# Altium schematic sheet PSU + RTC.SchDoc
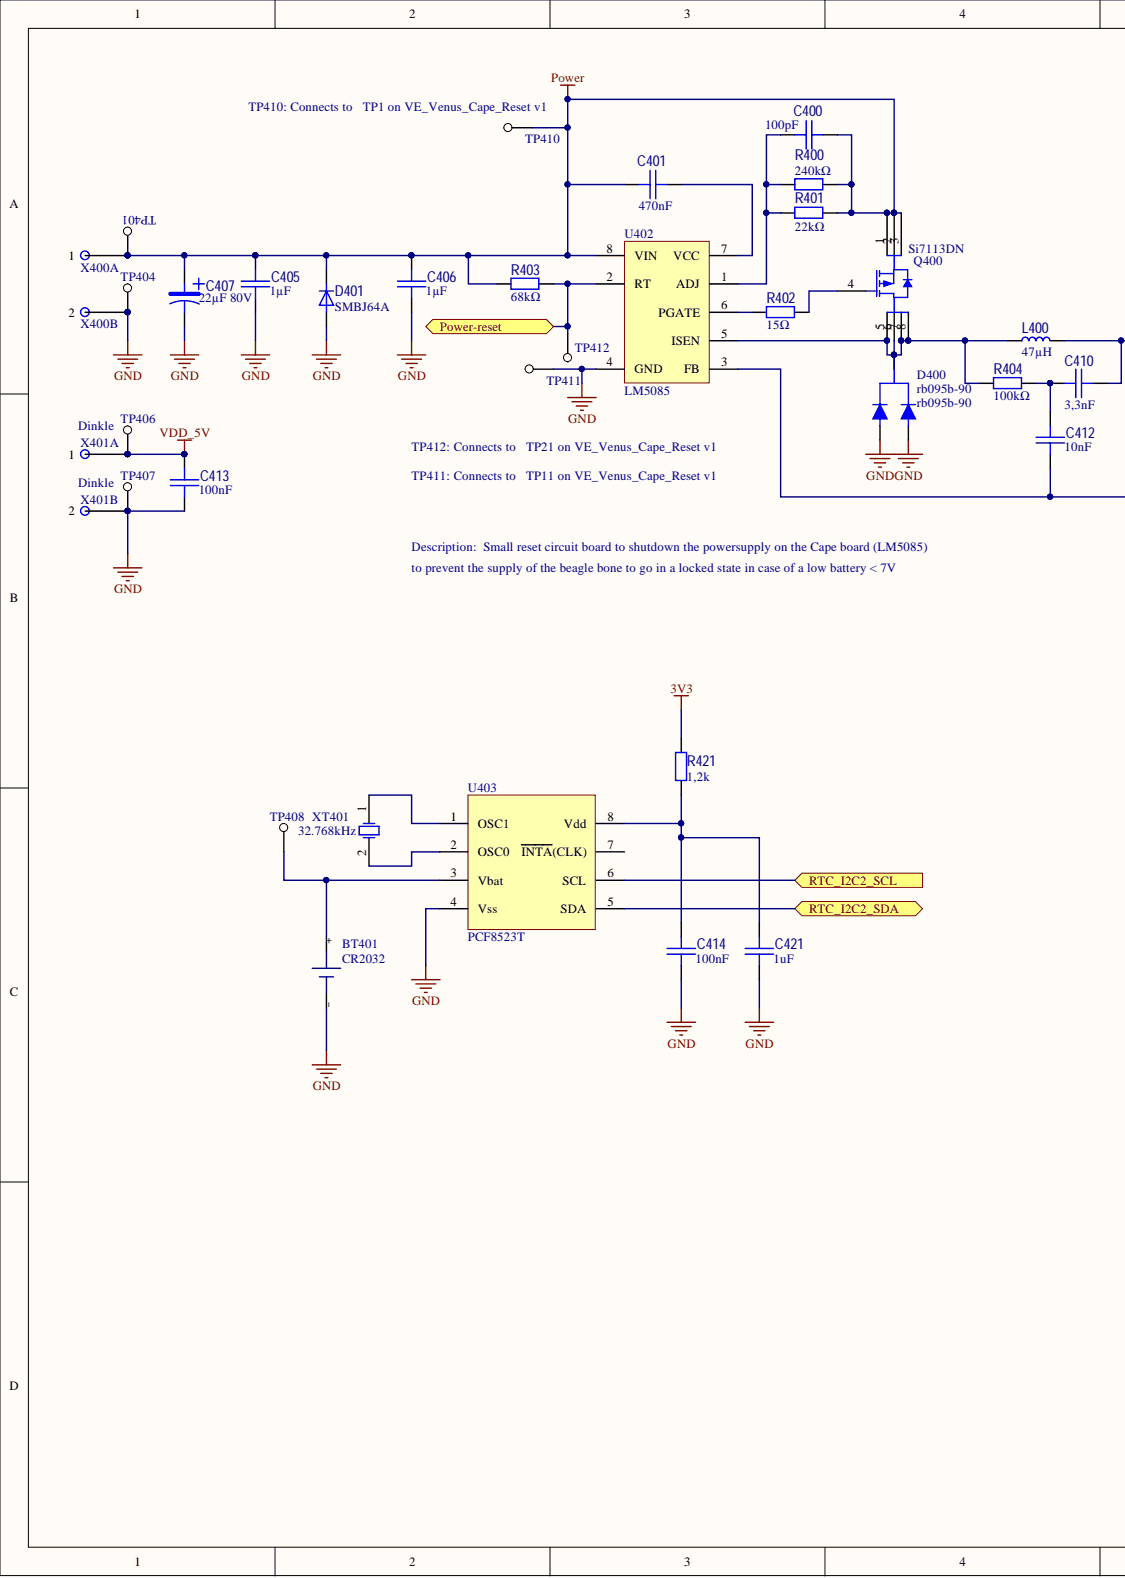
[diagram p0: PSU + RTC.SchDoc, left]
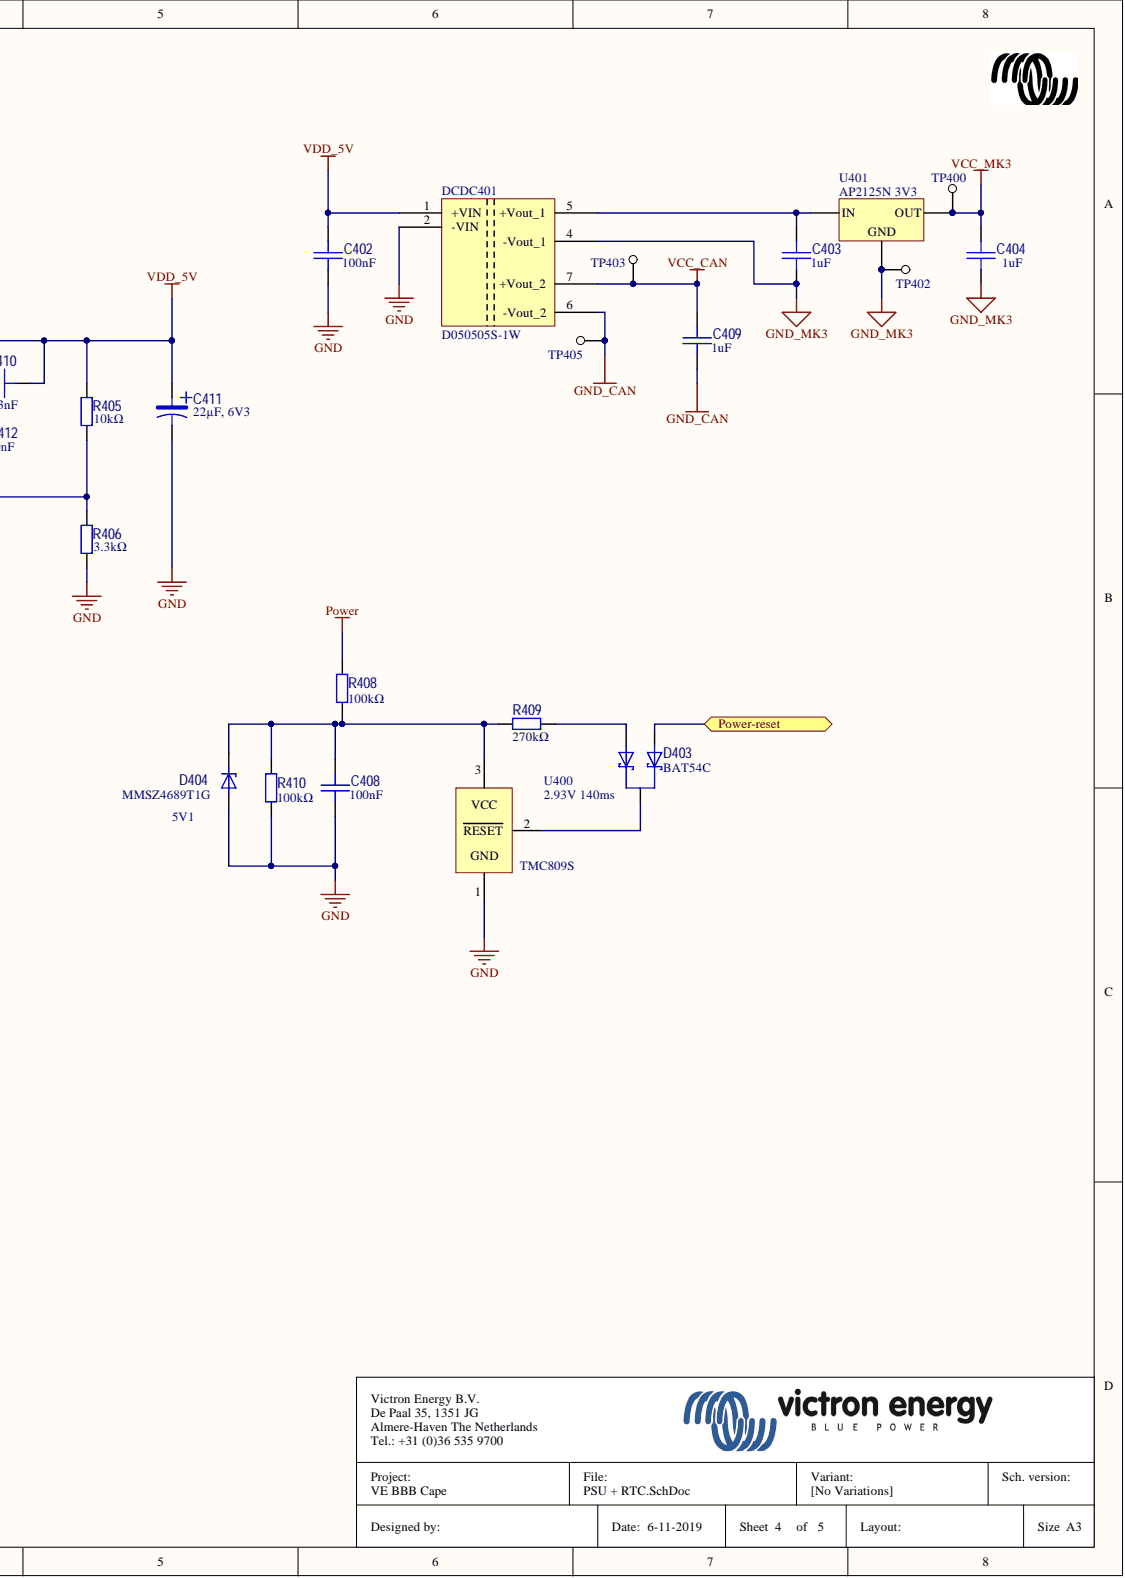
[diagram p1: PSU + RTC.SchDoc, right]

## Parts
BT401  CR2032  [CR2032HFN]
C400  value 100pF  [C0805_R]  (hidden comment: 100419)
C401  value 470nF  [C0805_R]  (hidden comment: 1044)
C402  value 100nF  [C0805_R]  (hidden comment: 1035)
C403  value 1uF  [C0805_R]  (hidden comment: 100185)
C404  value 1uF  [C0805_R]  (hidden comment: 100185)
C405  value 1µF  [C1206_R]  (hidden comment: 100237)
C406  value 1µF  [C1206_R]  (hidden comment: 100237)
C407  value 22µF 80V  [ELCO_8X10_V]  (hidden comment: 100401)
C408  value 100nF  [C0805_R]  (hidden comment: 1035)
C409  value 1uF  [C0805_R]  (hidden comment: 100185)
C410  value 3,3nF  [C0805_R]  (hidden comment: 1082)
C411  value 22µF, 6V3  [ELCO_6.3X5.8_V]  (hidden comment: 100402)
C412  value 10nF  [C0805_R]  (hidden comment: 100420)
C413  value 100nF  [C0805_R]  (hidden comment: 1035)
C414  value 100nF  [C0805_R]  (hidden comment: 1035)
C421  value 1uF  [C0805_R]  (hidden comment: 1035)
D400  rb095b-90  [TO252_R]
D401  value SMBJ64A  [SMB/DO-214AA]  (hidden comment: 4014)
D403  BAT54C  [SOT23_R]
D404  MMSZ4689T1G  [SOD123_R]
DCDC401  value D050505S-1W  MAN_PARTNUMBER: D050505S-1W  [Recom SIP DCDC 1W]  (hidden comment: D_S-1W)
L400  value 47µH  [WE-PD-XXL]  (hidden comment: 101828)
Q400  Si7113DN  [PowerPak 1212-8]
R400  value 240kΩ  [R0805_R]  (hidden comment: 429)
R401  value 22kΩ  [R0805_R]  (hidden comment: 404)
R402  value 15Ω  [R0805_R]  (hidden comment: 328)
R403  value 68kΩ  [R0805_R]  (hidden comment: 416)
R404  value 100kΩ  [R0805_R]  (hidden comment: 420)
R405  value 10kΩ  [R0805_R]  (hidden comment: 396)
R406  value 3.3kΩ  [R0805_R]  (hidden comment: 384)
R408  value 100kΩ  [R0805_R]  (hidden comment: 420)
R409  value 270kΩ  [R0805_R]  (hidden comment: 420)
R410  value 100kΩ  [R0805_R]  (hidden comment: 420)
R421  value 1,2k  [R0805_R]  (hidden comment: 420)
TP400  CN1  [testpad_round_1mm]  (value not drawn)  (no BOM)
TP401  CN1  [testpad_round_1mm]  (value not drawn)  (no BOM)
TP402  CN1  [testpad_round_1mm]  (value not drawn)  (no BOM)
TP403  CN1  [testpad_round_1mm]  (value not drawn)  (no BOM)
TP404  CN1  [testpad_round_1mm]  (value not drawn)  (no BOM)
TP405  CN1  [testpad_round_1mm]  (value not drawn)  (no BOM)
TP406  CN1  [testpad_round_1mm]  (value not drawn)  (no BOM)
TP407  CN1  [testpad_round_1mm]  (value not drawn)  (no BOM)
TP408  CN1  [testpad_round_1mm]  (value not drawn)  (no BOM)
TP410  CN1  [testpad_round_1mm]  (value not drawn)  (no BOM)
TP411  CN1  [testpad_round_1mm]  (value not drawn)  (no BOM)
TP412  CN1  [testpad_round_1mm]  (value not drawn)  (no BOM)
U400  value 2.93V 140ms  [SOT23_R]  (hidden comment: MAX803)
U401  value AP2125N 3V3  [SOT23_R]  (hidden comment: regulator)
U402  LM5085  [HVSSOP-8]
U403  PCF8523T  [SO8_R]
X400  pluggable terminal 2P  [2EHDRC-2P]  (value not drawn)  (parts A,B)
X401  value Dinkle  MANUFACTURER: Dinkle  [ECH350R-2P]  (hidden comment: 2 pin terminal)  (parts A,B)
XT401  32.768kHz  [ABRA-ABS07-2_V]

## Ports
Power-reset, RTC_I2C2_SCL, RTC_I2C2_SDA

## Nets
3V3: R421.2
GND: BT401.1 C402.2 C405.2 C406.2 C407.2 C408.2 C411.2 C413.2 C414.2 C421.2 D400.1 D400.3 D401.2 D404.2 DCDC401.2(-VIN) R406.2 R410.1 TP404.1 TP407.1 TP411.1 U400.1 U402.4(GND) U403.4(Vss) X400.2 X401.2
GND_CAN: C409.2 DCDC401.6(-Vout_2) TP405.1
GND_MK3: C403.2 C404.2 DCDC401.4(-Vout_1) TP402.1 U401.1
Power: C400.2 C401.1 C405.1 C406.1 C407.1 D401.1 Q400.1 Q400.2 Q400.3 R400.2 R401.2 R403.2 R408.2 TP401.1 TP410.1 U402.8(VIN) X400.1
VCC_CAN: C409.1 DCDC401.7(+Vout_2) TP403.1
VCC_MK3: C404.1 TP400.1 U401.2
VDD_5V: C402.1 C410.2 C411.1 C413.1 DCDC401.1(+VIN) L400.1 R405.1 TP406.1 X401.1
Power-reset: D403.1 R403.1 TP412.1 U402.2(RT) ; port Power-reset
RTC_I2C2_SCL: U403.6(SCL) ; port RTC_I2C2_SCL
RTC_I2C2_SDA: U403.5(SDA) ; port RTC_I2C2_SDA
NetBT401_0: BT401.0 TP408.1 U403.3(Vbat)
NetC400_1: C400.1 R400.1 R401.1 U402.1(ADJ)
NetC401_2: C401.2 U402.7(VCC)
NetC403_1: C403.1 DCDC401.5(+Vout_1) U401.3
NetC408_1: C408.1 D404.1 R408.1 R409.2 R410.2 U400.3
NetC410_1: C410.1 C412.2 R404.2
NetC412_1: C412.1 R405.2 R406.1 U402.3(FB)
NetC414_1: C414.1 C421.1 R421.1 U403.8(Vdd)
NetD400_4: D400.4 L400.2 Q400.5 Q400.6 Q400.7 Q400.8 R404.1 U402.5(ISEN)
NetD403_2: D403.2 R409.1
NetD403_3: D403.3 U400.2
NetQ400_4: Q400.4 R402.1
NetR402_2: R402.2 U402.6(PGATE)
NetU403_1: U403.1(OSC1) XT401.1
NetU403_2: U403.2(OSC0) XT401.2

Not connected: U403 7(INTA(CLK))

## Library data of the parts
BT401 = 101562: CR2032 solder pins; MANUFACTURER: Panasonic; Cls: MECH; Database Table Name: Mechanical; Component Kind: Standard; MAN_PARTNUMBER: CR2032HFN; Part: 101562; PartID: 101562; Supplier 1: Digikey; Supplier Part Number 1: P666-ND; Supplier Price 1: 0,608; Value: CR2032; Library Name: VictronAltiumComponents.SVNDBLib
C400 = 100419: MAN_PARTNUMBER: C0805C101J1GACTU; MANUFACTURER: KEMET; Part_Description: CAP,100PF,100V,0805,NP0; Cls: CAP; Database Table Name: COMPDATA$; Shape: 805; Tol: 0.05; VENDOR: Digikey; VENDOR_PRICE: 0.01426; VENDOR_STOCK_NR: 399-1123-2-ND; Voltage: 100V; Component Kind: Standard; Part: 100419; Library Name: Data Base Librarys.DbLib
C401 = 1044: INDIC_PARTNO: CSEC470NFH0KFYAY; MAN_PARTNUMBER: CC0805KKX7R9BB474; MANUFACTURER: Yageo; Part_Description: Cap, Smd Ml.Ceramic 0805 470NF 10% 50V X7R, Yageo; Cls: CAP; Database Table Name: COMPDATA$; INDIC_PRICE: 0.0315; Shape: 805; Tol: 0.1; VENDOR: Digikey; VENDOR_PRICE: 0.05409; VENDOR_STOCK_NR: 311-1889-1-ND; Voltage: 50V; Component Kind: Standard; Part: 1044; Library Name: Data Base Librarys.DbLib
C402 C408 C413 C414 = 1035: Cap, SMD 0805, ceramic, X7R, 100nF, 50V, 10%, Yageo; INDIC_PARTNO: CSE100NF0805YAGY; MAN_PARTNUMBER: CC0805KPX7R9BB104; Database Table Name: AllComponents; INDIC_PRICE: 0,068; Shape: 805; Tol: 10,00%; Cls: CAP; Component Kind: Standard; MANUFACTURER: Yageo; Part: 1035; PartID: 1035; Supplier 1: Mouser; Supplier Part Number 1: 603-CC805KPX7R9BB104; Supplier Price 1: 0,005; Voltage: 50V; Library Name: VictronAltiumComponents.SVNDBLib
C403 C404 C409 = 100185: MAN_PARTNUMBER: C0805C105K4RACTU; MANUFACTURER: Kemet; Part_Description: Cap, MLCC, X7R, 16V, 10%, 0805, 1uF; Cls: CAP; Database Table Name: COMPDATA$; VENDOR: Digikey; VENDOR_PRICE: 0.0222; VENDOR_STOCK_NR: 399-1284-1-ND; Component Kind: Standard; Part: 100185; Library Name: Data Base Librarys.DbLib
C405 C406 = 100237: MAN_PARTNUMBER: C1206C105K1RACTU; MANUFACTURER: Kemet; Part_Description: CAP, MLCC, X7R, 1UF, 100V, 1206; Cls: CAP; Database Table Name: COMPDATA$; Shape: 1206; VENDOR: Digikey; VENDOR_PRICE: 0.13915; VENDOR_STOCK_NR: 399-11683-1-ND; Component Kind: Standard; Part: 100237; Library Name: Data Base Librarys.DbLib
C407 = 100401: MAN_PARTNUMBER: EEH-ZA1K220P; MANUFACTURER: Panasonic; Part_Description: Cap polarized, hybrid polymer. 80V 22µF; Cls: CAP; Database Table Name: COMPDATA$; VENDOR: Mouser; VENDOR_PRICE: 0.958; VENDOR_STOCK_NR: 667-EEH-ZA1K220P; Component Kind: Standard; Part: 100401; Library Name: Data Base Librarys.DbLib
C410 = 1082: INCAP_PARTNO: 1005520681; MAN_PARTNUMBER: C0805KRX7R0BB332; MANUFACTURER: Yageo; Part_Description: CAP,3.3nF,100V, 0805; Cls: CAP; Database Table Name: COMPDATA$; INCAP_PRICE: 0.0032; Shape: 805; VENDOR: Digikey; VENDOR_PRICE: 0.01802; VENDOR_STOCK_NR: 311-1471-2-ND; Component Kind: Standard; Part: 1082; Library Name: Data Base Librarys.DbLib
C411 = 100402: MAN_PARTNUMBER: 6SVP220M; MANUFACTURER: Panasonic; Part_Description: Cap polarized, polymer. 6.3V 220µF; Cls: CAP; Database Table Name: COMPDATA$; VENDOR: Mouser; VENDOR_PRICE: 0.339; VENDOR_STOCK_NR: 667-6SVP220M; Component Kind: Standard; Part: 100402; Library Name: Data Base Librarys.DbLib
C412 = 100420: MAN_PARTNUMBER: C0805C101K5RACTU; MANUFACTURER: KEMET; Part_Description: CAP,10nF,MLC X7R 50V,0805; Cls: CAP; Database Table Name: COMPDATA$; Shape: 805; Tol: 0.1; VENDOR: Digikey; VENDOR_PRICE: 0.038; VENDOR_STOCK_NR: 399-7981-2-ND; Voltage: 50V; Component Kind: Standard; Part: 100420; Library Name: Data Base Librarys.DbLib
C421 = 1035: Cap, SMD 0805, ceramic, X7R, 100nF, 50V, 10%, Yageo; INDIC_PARTNO: CSE100NF0805YAGY; MAN_PARTNUMBER: CC0805KPX7R9BB104; Database Table Name: AllComponents; INDIC_PRICE: 0,068; Shape: 805; Tol: 10,00%; Voltage: 10V; Cls: CAP; Component Kind: Standard; MANUFACTURER: Yageo; Part: 1035; PartID: 1035; Supplier 1: Mouser; Supplier Part Number 1: 603-CC805KPX7R9BB104; Supplier Price 1: 0,005; Library Name: VictronAltiumComponents.SVNDBLib
D400 = 101551: MANUFACTURER: Rohm; Cls: DIO; Database Table Name: AllComponents; Shape: TO 252 Dpak; Component Kind: Standard; Description: rb095b-90; MAN_PARTNUMBER: rb095b-90; Part: 101551; PartID: 101551; Supplier 1: Digikey; Supplier Part Number 1: RB095B-90TLTR-ND; Supplier Price 1: 0,3598; Value: rb095b-90; Library Name: VictronAltiumComponents.SVNDBLib
D401 = 4014: MAN_PARTNUMBER: ES2B; MANUFACTURER: Vishay; Part_Description: Diode,SMD ,2A 100V, ES2B, SMB; Cls: DIO; Database Table Name: COMPDATA$; VENDOR: Farnell; VENDOR_PRICE: 0.154; VENDOR_STOCK_NR: 9549250; Component Kind: Standard; Part: 4014; Library Name: Data Base Librarys.DbLib
D403 = 4003: Diode, SMD, 30V, 200mA, BAT54C, SOT23, dual common cathode; ACM_PARTNO: 8579; INCAP_PARTNO: 1009100229; IRRICHI_PARTNO: 2403314730000; MAN_PARTNUMBER: BAT54C,215; MANUFACTURER: Nexperia; ACM_PRICE: 0,0151; Cls: DIO; Current: 0.2; Database Table Name: AllComponents; INCAP_PRICE: 0,0084; IRRICHI_PRICE: 0,016; Voltage: 30; Component Kind: Standard; Part: 4003; PartID: 4003; Shape: SOT23; Supplier 1: Farnell; Supplier Part Number 1: 1081192; Supplier Price 1: 0,009; Value: BAT54C; Library Name: VictronAltiumComponents.SVNDBLib
D404 = 101698: Diode, SMD, Zener, 15V, 500mW, MMSZ5245B-TP; ACM_PARTNO: 0DIZN-041PbF; MANUFACTURER: MCC; ACM_PRICE: 0,0187; Cls: DIO; Current: 0.5; Database Table Name: AllComponents; Shape: SOD123; Voltage: 15; Component Kind: Standard; ComponentLink1Description: Datasheet; ComponentLink1URLSrc: http://www.mccsemi.com/up_pdf/MMSZ5221-MMSZ5261(SOD123).pdf; MAN_PARTNUMBER: MMSZ5245B-TP; Part: 101698; PartID: 101698; Supplier 1: Digikey; Supplier Part Number 1: MMSZ5245B-TPMSTR-ND; Supplier Price 1: 0,010; Value: MMSZ4689T1G; Library Name: VictronAltiumComponents.SVNDBLib
DCDC401 = 101516: IC, controller, buck, 5V, 100mA, Mornsun unregulated twin DC-DC Converter; Database Table Name: AllComponents; Shape: TH; Cls: IC; Component Kind: Standard; MANUFACTURER: Mornsun; Part: 101516; PartID: 101516; Supplier Price 1: 2,28; Library Name: VictronAltiumComponents.SVNDBLib
L400 = 101828: Choke SMD 47µH; MAN_PARTNUMBER: SRR1210A-470M; MANUFACTURER: Bourns; Cls: IND; Database Table Name: Inductor; Shape: TH; Component Kind: Standard; Part: 101828; PartID: 101828; Supplier 1: Digikey; Supplier Part Number 1: SRR1210A-470MCT-ND; Supplier Price 1: 0,51870; Library Name: VictronAltiumComponents.SVNDBLib
Q400 = 100400: MAN_PARTNUMBER: SI7113DN-T1-GE3; MANUFACTURER: Vishay Siliconix; Part_Description: PMOS, SI7113DN, -100V 134mOhm, PowerPAK SO8; Cls: FET; Database Table Name: COMPDATA$; VENDOR: Mouser; VENDOR_PRICE: 0.669; VENDOR_STOCK_NR: 781-SI7113DN-T1-GE3; Component Kind: Standard; Part: 100400; Value: Si7113DN; Library Name: Data Base Librarys.DbLib
R400 = 429: MAN_PARTNUMBER: RC0805FR-07240KL; MANUFACTURER: YAGEO; Part_Description: RES,MF,240K, 0.125W 1% 0805; Cls: RES; Database Table Name: COMPDATA$; INCAP_PRICE: 0.0014; Power: 0,125W; Shape: 805; Tol: 0.01; VENDOR: Mouser; VENDOR_PRICE: 0.003; VENDOR_STOCK_NR: 603-RC0805FR-07240KL; Component Kind: Standard; ComponentLink1Description: \datasheets\Yageo-RC0805FR-0710KL-datasheet.pdf; Part: 429; Library Name: Data Base Librarys.DbLib
R401 = 404: INCAP_PARTNO: 1035031293; INDIC_PARTNO: RSCEE0022KF97MUY; MAN_PARTNUMBER: RC0805FR-0722KL; MANUFACTURER: YAGEO; Part_Description: RES,MF,22K   0.125W 1% 0805; Cls: RES; Database Table Name: COMPDATA$; INCAP_PRICE: 0.0014; INDIC_PRICE: 0.0023; Power: 0,125W; Shape: 805; Tol: 0.01; VENDOR: Mouser; VENDOR_PRICE: 0.003; VENDOR_STOCK_NR: 603-RC0805FR-0722KL; Component Kind: Standard; ComponentLink1Description: \datasheets\Yageo-RC0805FR-0710KL-datasheet.pdf; Part: 404; Library Name: Data Base Librarys.DbLib
R402 = 328: Res, SMD 0805, MF, 15E, 0.125W 1% 0805; MANUFACTURER: YAGEO; Database Table Name: Resistor; INCAP_PRICE: 0,0009; Power: 0,125W; Shape: 0805; Tol: 1,00%; Cls: RES; Component Kind: Standard; ComponentLink1Description: \datasheets\Yageo-RC0805FR-0710KL-datasheet.pdf; Part: 328; PartID: 328; Library Name: VictronAltiumComponents.SVNDBLib
R403 = 416: INDIC_PARTNO: RSCE68KXXFB8NYX; MAN_PARTNUMBER: RC0805FR-0768KL; MANUFACTURER: YAGEO; Part_Description: RES,MF,68K, 0.125W 1% 0805; Cls: RES; Database Table Name: COMPDATA$; INCAP_PRICE: 0.0014; INDIC_PRICE: 0.0018; Power: 0,125W; Shape: 805; Tol: 0.01; VENDOR: Mouser; VENDOR_PRICE: 0.003; VENDOR_STOCK_NR: 603-RC0805FR-0768KL; Component Kind: Standard; ComponentLink1Description: \datasheets\Yageo-RC0805FR-0710KL-datasheet.pdf; Part: 416; Library Name: Data Base Librarys.DbLib
R404 R408-R410 R421 = 420: Res, SMD 0805, MF, 100K 0.125W 1% 0805; INCAP_PARTNO: 1035031298; INDIC_PARTNO: RSCE100KXFB8NYX; MAN_PARTNUMBER: RC0805FR-07 100KL; MANUFACTURER: YAGEO; Database Table Name: Resistor; INCAP_PRICE: 0,0009; INDIC_PRICE: 0,0008; Power: 0,125W; Shape: 0805; Tol: 1,00%; Cls: RES; Component Kind: Standard; ComponentLink1Description: \datasheets\Yageo-RC0805FR-0710KL-datasheet.pdf; Part: 420; PartID: 420; Library Name: VictronAltiumComponents.SVNDBLib
R405 = 396: INCAP_PARTNO: 1035031290; INDIC_PARTNO: RSCE00010KFB7YAY; MAN_PARTNUMBER: RC0805FR-0710KL; MANUFACTURER: YAGEO; Part_Description: RES,MF,10K  0.125W 1%0805; Cls: RES; Database Table Name: COMPDATA$; INCAP_PRICE: 0.0014; INDIC_PRICE: 0.0018; Power: 0,125W; Shape: 805; Tol: 0.01; VENDOR: Mouser; VENDOR_PRICE: 0.003; VENDOR_STOCK_NR: 603-RC0805FR-0710KL; Component Kind: Standard; ComponentLink1Description: \datasheets\Yageo-RC0805FR-0710KL-datasheet.pdf; Part: 396; Library Name: Data Base Librarys.DbLib
R406 = 384: INDIC_PARTNO: RSCEO3K300FB6YAY; MAN_PARTNUMBER: RC0805FR-073K3L; MANUFACTURER: YAGEO; Part_Description: RES,MF,3K3, 0.125W 1% 0805; Cls: RES; Database Table Name: COMPDATA$; INCAP_PRICE: 0.0014; INDIC_PRICE: 0.0076; Power: 0,125W; Shape: 805; Tol: 0.01; VENDOR: Mouser; VENDOR_PRICE: 0.003; VENDOR_STOCK_NR: 603-RC0805FR-073K3L; Component Kind: Standard; ComponentLink1Description: \datasheets\Yageo-RC0805FR-0710KL-datasheet.pdf; Part: 384; Library Name: Data Base Librarys.DbLib
TP400-TP408 TP410-TP412 = 100320: Testpad, Round, D=1mm; Cls: CON; Database Table Name: Connector; Part: 100320; PartID: 100320; Library Name: VictronAltiumComponents.SVNDBLib
U400 = 101827: IC, reset monitor, 2.93V, 140ms, SOT-23, NCP803, open drain; MAN_PARTNUMBER: NCP803SN293T1G; MANUFACTURER: ON Semiconductor; Database Table Name: AllComponents; Shape: SOT23; Value: 2.93V 140ms; Cls: IC; Component Kind: Standard; Part: 101827; PartID: 101827; Supplier 1: Digikey; Supplier Part Number 1: NCP803SN293T1GOSCT-ND; Supplier Price 1: 0,22138; Library Name: VictronAltiumComponents.SVNDBLib
U401 = 100431: MAN_PARTNUMBER: AP2125N-3.3TRG1; MANUFACTURER: Diodes Inc.; Part_Description: LDO Regulator 3V3; Cls: IC; Database Table Name: COMPDATA$; VENDOR: Mouser; VENDOR_PRICE: 0.077; VENDOR_STOCK_NR: 621-AP2125N-3.3TRG1; Component Kind: Standard; Part: 100431; Library Name: Data Base Librarys.DbLib
U402 = 101556: IC, controller, buck, 75V; MAN_PARTNUMBER: LM5085MY/NOPB; MANUFACTURER: TI; Cls: IC; Component Kind: Standard; Database Table Name: IC; Part: 101556; PartID: 101556; Shape: HVSSOP-8; Supplier 1: Digikey; Supplier Part Number 1: LM5085MY/NOPBCT-ND; Supplier Price 1: 0,95625; Value: LM5085; Library Name: VictronAltiumComponents.SVNDBLib
U403 = 101555: IC, RTC, I2C; MANUFACTURER: NXP; Database Table Name: AllComponents; Shape: SOIC8; Cls: IC; Component Kind: Standard; MAN_PARTNUMBER: PCF8523T; Part: 101555; PartID: 101555; Supplier 1: Digikey; Supplier Part Number 1: 568-5306-1-ND; Supplier Price 1: 0,58590; Value: PCF8523T; Library Name: VictronAltiumComponents.SVNDBLib
X400 = 101569: 2EHDRC-2P; MANUFACTURER: 2EHDRC-2P + 2ESDV-2P; Cls: CON; Database Table Name: AllComponents; Component Kind: Standard; Part: 101569; PartID: 101569; Library Name: VictronAltiumComponents.SVNDBLib
X401 = 100409: Part_Description: 2 pin Pluggable terminal Dinkle ECH350R; Cls: CON; Database Table Name: COMPDATA$; VENDOR: ASTA TECHNOLOGIES; VENDOR_PRICE: 0.4261; Component Kind: Standard; MAN_PARTNUMBER: ECH350R-2P; Part: 100409; Library Name: Data Base Librarys.DbLib
XT401 = 100432: Crystal Unit; MAN_PARTNUMBER: ABS07-32.768KHZ-9-T; MANUFACTURER: ABRACON; Part_Description: CRYSTAL, 32.768KHZ, 9PF, SMD; Cls: XTAL; Database Table Name: COMPDATA$; VENDOR: Mouser; VENDOR_PRICE: 0.383; VENDOR_STOCK_NR: 815-ABS07-32.768K9T; 2nd supplier: AVNET; 2nd supplier part no#: ABS07-32.768KHZ-9-T; 2nd supplier price: 0.39; Component Kind: Standard; Part: 100432; Value: 32.768kHz; Library Name: Data Base Librarys.DbLib
